# Altium schematic sheet Microcontroller.SchDoc
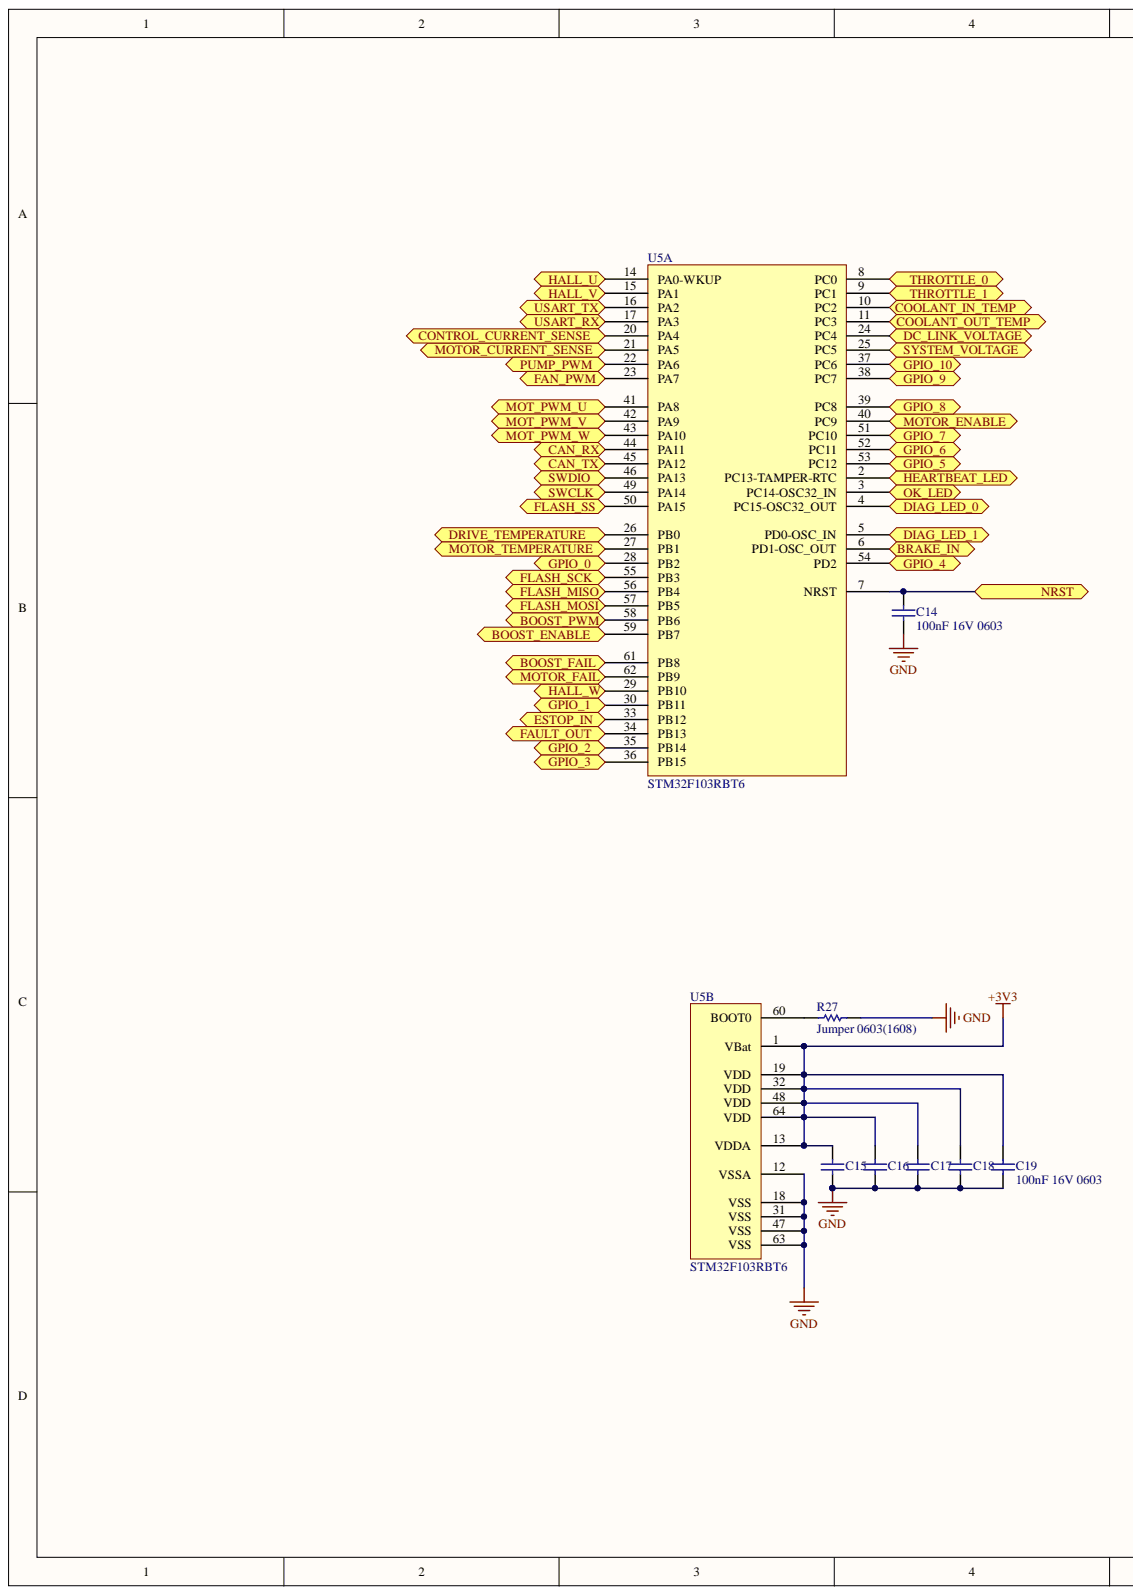
[diagram p0: Microcontroller.SchDoc, left]
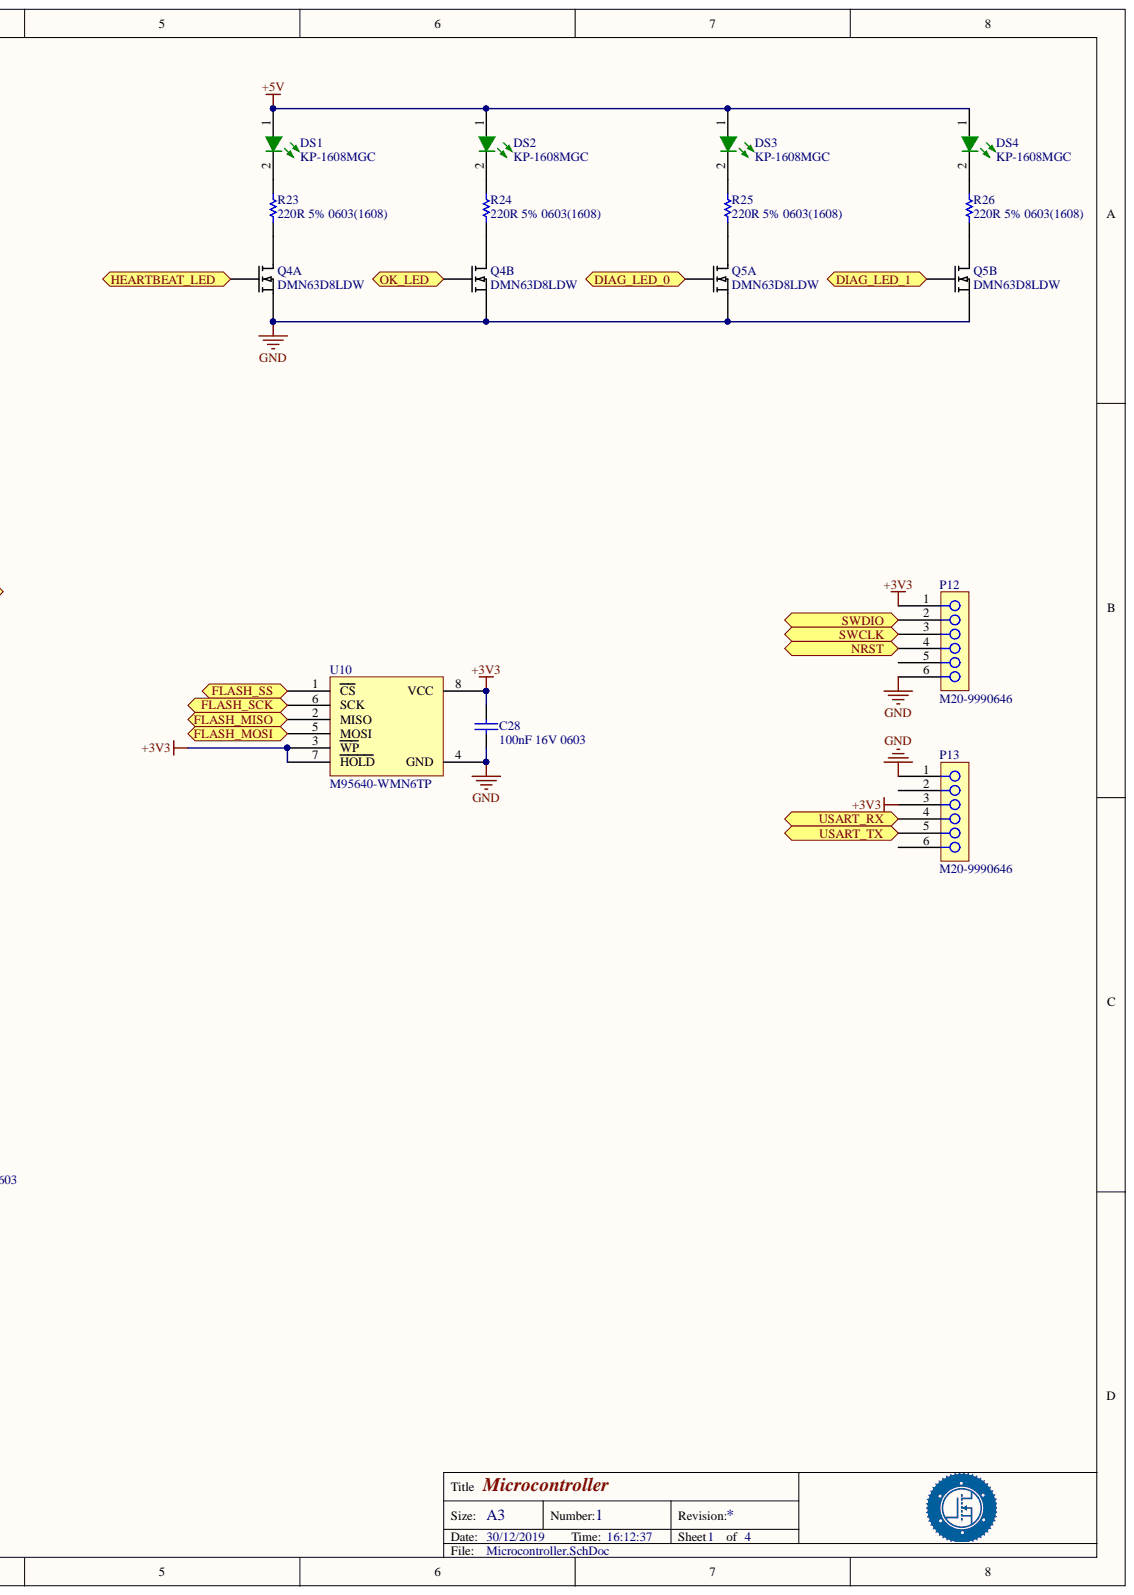
[diagram p1: Microcontroller.SchDoc, right]

## Parts
C14  100nF 16V 0603  value 100nF  [CAPC0603(1608)100_N]
C15  CAP 100nF 16V 0603(1608)  [CAPC0603(1608)100_N]  (value not drawn)
C16  CAP 100nF 16V 0603(1608)  [CAPC0603(1608)100_N]  (value not drawn)
C17  CAP 100nF 16V 0603(1608)  [CAPC0603(1608)100_N]  (value not drawn)
C18  value 100nF  [CAPC0603(1608)100_N]  (hidden comment: CAP 100nF 16V 0603(1608))
C19  100nF 16V 0603  value 100nF  [CAPC0603(1608)100_N]
C28  100nF 16V 0603  value 100nF  [CAPC0603(1608)100_N]
DS1  KP-1608MGC  [KING-0603LED_GREEN_V]
DS2  KP-1608MGC  [KING-0603LED_GREEN_V]
DS3  KP-1608MGC  [KING-0603LED_GREEN_V]
DS4  KP-1608MGC  [KING-0603LED_GREEN_V]
P12  M20-9990646  [HEADER_6]
P13  M20-9990646  [HEADER_6]
Q4  DMN63D8LDW  [SOT23-6]  (parts A,B)
Q5  DMN63D8LDW  [SOT23-6]  (parts A,B)
R23  220R 5% 0603(1608)  [RESC0603(1608)_N]
R24  220R 5% 0603(1608)  [RESC0603(1608)_N]
R25  220R 5% 0603(1608)  [RESC0603(1608)_N]
R26  220R 5% 0603(1608)  [RESC0603(1608)_N]
R27  Jumper 0603(1608)  [RESC0603(1608)_N]
U5  STM32F103RBT6  [LQFP64]  (parts A,B)
U10  M95640-WMN6TP  [SOP8]

## Ports
BOOST_ENABLE, BOOST_FAIL, BOOST_PWM, BRAKE_IN, CAN_RX, CAN_TX, CONTROL_CURRENT_SENSE, COOLANT_IN_TEMP, COOLANT_OUT_TEMP, DC_LINK_VOLTAGE, DIAG_LED_0, DIAG_LED_1, DRIVE_TEMPERATURE, ESTOP_IN, FAN_PWM, FAULT_OUT, FLASH_MISO, FLASH_MOSI, FLASH_SCK, FLASH_SS, GPIO_0, GPIO_1, GPIO_2, GPIO_3, GPIO_4, GPIO_5, GPIO_6, GPIO_7, GPIO_8, GPIO_9, GPIO_10, HALL_U, HALL_V, HALL_W, HEARTBEAT_LED, MOT_PWM_U, MOT_PWM_V, MOT_PWM_W, MOTOR_CURRENT_SENSE, MOTOR_ENABLE, MOTOR_FAIL, MOTOR_TEMPERATURE, NRST, OK_LED, PUMP_PWM, SWCLK, SWDIO, SYSTEM_VOLTAGE, THROTTLE_0, THROTTLE_1, USART_RX, USART_TX

## Nets
+3V3: C15.2 C16.2 C17.2 C18.2 C19.2 C28.2 P12.1 P13.3 U5.1(VBat) U5.13(VDDA) U5.19(VDD) U5.32(VDD) U5.48(VDD) U5.64(VDD) U10.3(WP) U10.7(HOLD) U10.8(VCC)
+5V: DS1.1 DS2.1 DS3.1 DS4.1
GND: C14.1 C15.1 C16.1 C17.1 C18.1 C19.1 C28.1 P12.6 P13.1 Q4.1 Q4.4 Q5.1 Q5.4 R27.1 U5.12(VSSA) U5.18(VSS) U5.31(VSS) U5.47(VSS) U5.63(VSS) U10.4(GND)
BOOST_ENABLE: U5.59(PB7) ; port BOOST_ENABLE
BOOST_FAIL: U5.61(PB8) ; port BOOST_FAIL
BOOST_PWM: U5.58(PB6) ; port BOOST_PWM
BRAKE_IN: U5.6(PD1-OSC_OUT) ; port BRAKE_IN
CAN_RX: U5.44(PA11) ; port CAN_RX
CAN_TX: U5.45(PA12) ; port CAN_TX
CONTROL_CURRENT_SENSE: U5.20(PA4) ; port CONTROL_CURRENT_SENSE
COOLANT_IN_TEMP: U5.10(PC2) ; port COOLANT_IN_TEMP
COOLANT_OUT_TEMP: U5.11(PC3) ; port COOLANT_OUT_TEMP
DC_LINK_VOLTAGE: U5.24(PC4) ; port DC_LINK_VOLTAGE
DIAG_LED_0: Q5.2 U5.4(PC15-OSC32_OUT) ; port DIAG_LED_0
DIAG_LED_1: Q5.5 U5.5(PD0-OSC_IN) ; port DIAG_LED_1
DRIVE_TEMPERATURE: U5.26(PB0) ; port DRIVE_TEMPERATURE
ESTOP_IN: U5.33(PB12) ; port ESTOP_IN
FAN_PWM: U5.23(PA7) ; port FAN_PWM
FAULT_OUT: U5.34(PB13) ; port FAULT_OUT
FLASH_MISO: U5.56(PB4) U10.2(MISO) ; port FLASH_MISO
FLASH_MOSI: U5.57(PB5) U10.5(MOSI) ; port FLASH_MOSI
FLASH_SCK: U5.55(PB3) U10.6(SCK) ; port FLASH_SCK
FLASH_SS: U5.50(PA15) U10.1(CS) ; port FLASH_SS
GPIO_0: U5.28(PB2) ; port GPIO_0
GPIO_1: U5.30(PB11) ; port GPIO_1
GPIO_2: U5.35(PB14) ; port GPIO_2
GPIO_3: U5.36(PB15) ; port GPIO_3
GPIO_4: U5.54(PD2) ; port GPIO_4
GPIO_5: U5.53(PC12) ; port GPIO_5
GPIO_6: U5.52(PC11) ; port GPIO_6
GPIO_7: U5.51(PC10) ; port GPIO_7
GPIO_8: U5.39(PC8) ; port GPIO_8
GPIO_9: U5.38(PC7) ; port GPIO_9
GPIO_10: U5.37(PC6) ; port GPIO_10
HALL_U: U5.14(PA0-WKUP) ; port HALL_U
HALL_V: U5.15(PA1) ; port HALL_V
HALL_W: U5.29(PB10) ; port HALL_W
HEARTBEAT_LED: Q4.2 U5.2(PC13-TAMPER-RTC) ; port HEARTBEAT_LED
MOT_PWM_U: U5.41(PA8) ; port MOT_PWM_U
MOT_PWM_V: U5.42(PA9) ; port MOT_PWM_V
MOT_PWM_W: U5.43(PA10) ; port MOT_PWM_W
MOTOR_CURRENT_SENSE: U5.21(PA5) ; port MOTOR_CURRENT_SENSE
MOTOR_ENABLE: U5.40(PC9) ; port MOTOR_ENABLE
MOTOR_FAIL: U5.62(PB9) ; port MOTOR_FAIL
MOTOR_TEMPERATURE: U5.27(PB1) ; port MOTOR_TEMPERATURE
NRST: C14.2 P12.4 U5.7(NRST) ; port NRST
OK_LED: Q4.5 U5.3(PC14-OSC32_IN) ; port OK_LED
PUMP_PWM: U5.22(PA6) ; port PUMP_PWM
SWCLK: P12.3 U5.49(PA14) ; port SWCLK
SWDIO: P12.2 U5.46(PA13) ; port SWDIO
SYSTEM_VOLTAGE: U5.25(PC5) ; port SYSTEM_VOLTAGE
THROTTLE_0: U5.8(PC0) ; port THROTTLE_0
THROTTLE_1: U5.9(PC1) ; port THROTTLE_1
USART_RX: P13.4 U5.17(PA3) ; port USART_RX
USART_TX: P13.5 U5.16(PA2) ; port USART_TX
NetDS1_2: DS1.2 R23.1
NetDS2_2: DS2.2 R24.1
NetDS3_2: DS3.2 R25.1
NetDS4_2: DS4.2 R26.1
NetQ4_3: Q4.3 R24.2
NetQ4_6: Q4.6 R23.2
NetQ5_3: Q5.3 R26.2
NetQ5_6: Q5.6 R25.2
NetR27_2: R27.2 U5.60(BOOT0)

Not connected: P12 5; P13 2 6

## Library data of the parts
C14-C19 C28 = CMP-1035-02585-1: CAP 100nF 16V ±20% 0603 (1608 Metric) Thickness 1mm SMD; Case-EIA: 0603; Case-Metric: 1608; Tolerance: Â±20%; Component Kind: Standard; Library Name: Altium Content Vault; Max Thickness: 1 mm; Rated Voltage: 16 V; Technology: SMT
  C15-C17: Value: 100nF
DS1-DS4 = CMP-0404-00001-1: LED, SMT, 0603(1608), 1.1mm thickness, Green; Manufacturer: Kingbright; Forward Current: 30 mA; Packing Type: 2000 pcs / reel; Power Dissipation: 75 mW; Reverse Voltage: 5 V; Component Kind: Standard; ComponentLink1Description: Manufacturer URL; ComponentLink1URL: http://www.kingbright.com/; Library Name: Altium Content Vault; Mounting Technology: Surface Mount; PackageDescription: 2-Pin SMT LED, 1.6 x 0.8 mm Pitch; PackageReference: 0603LED_GREEN; PartNumber: KP-1608MGC; Storage Temperature: -40 to +85 degC
P12 P13 = Header_6: 6 Pos. Male SIL Vertical Throughboard Conn.; Manufacturer: Harwin; Component Kind: Standard; Library Name: sl-altium.SchLib; PartNumber: M20-9990646
Q4 Q5 = DMN63D8LDW: DUAL N-CHANNEL ENHANCEMENT MODE MOSFET; Manufacturer: Diodes Incorporated; Component Kind: Standard; Library Name: sl-altium.SchLib; PartNumber: DMN63D8LDW
R23-R26 = CMP-1012-00058-1: 220R 0.1W 5% 0603 (1608 Metric)  SMD; Case-EIA: 0603; Case-Metric: 1608; Value: 220.00 Ohm; Component Kind: Standard; Library Name: Altium Content Vault; Power: 0.1 W; Technology: SMT; Tolerance: 5 %
R27 = CMP-1012-00001-1: Jumper 0603 (1608 Metric); Case-EIA: 0603; Case-Metric: 1608; Power: None; Value: 0.00 Ohm; Component Kind: Standard; Library Name: Altium Content Vault; Technology: SMT; Tolerance: None
U5 = STM32F103RBT6: STM32 ARM Cortex M3 microcontroller; Manufacturer: STMicroelectronics; Component Kind: Standard; Library Name: sl-altium.SchLib; ManufacturerPartNumber: STM32F103RBT6
U10 = M95640-WMN6TP: 64-Kbit serial SPI bus EEPROM with high-speed clock; Manufacturer: STMicroelectronics; ManufacturerPartNumber: M95640-WMN6TG/K; Component Kind: Standard; Library Name: sl-altium.SchLib
